# Altium schematic sheet 2_mcu.SchDoc(2_mcu)
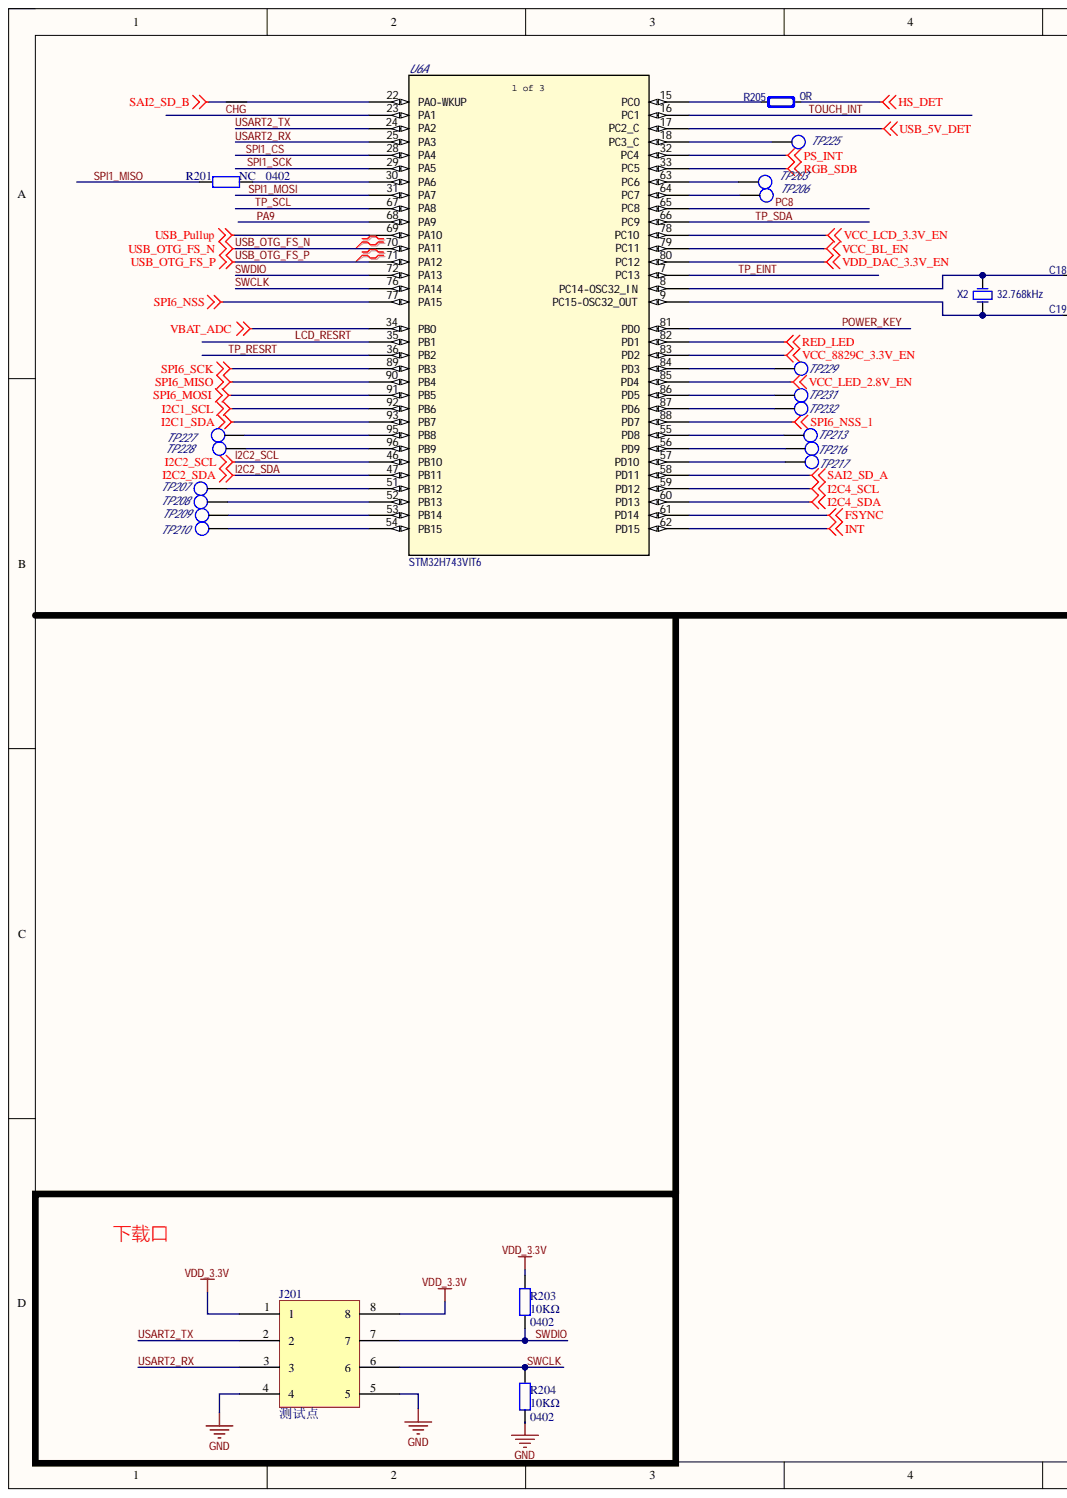
[diagram p0: 2_mcu.SchDoc, left]
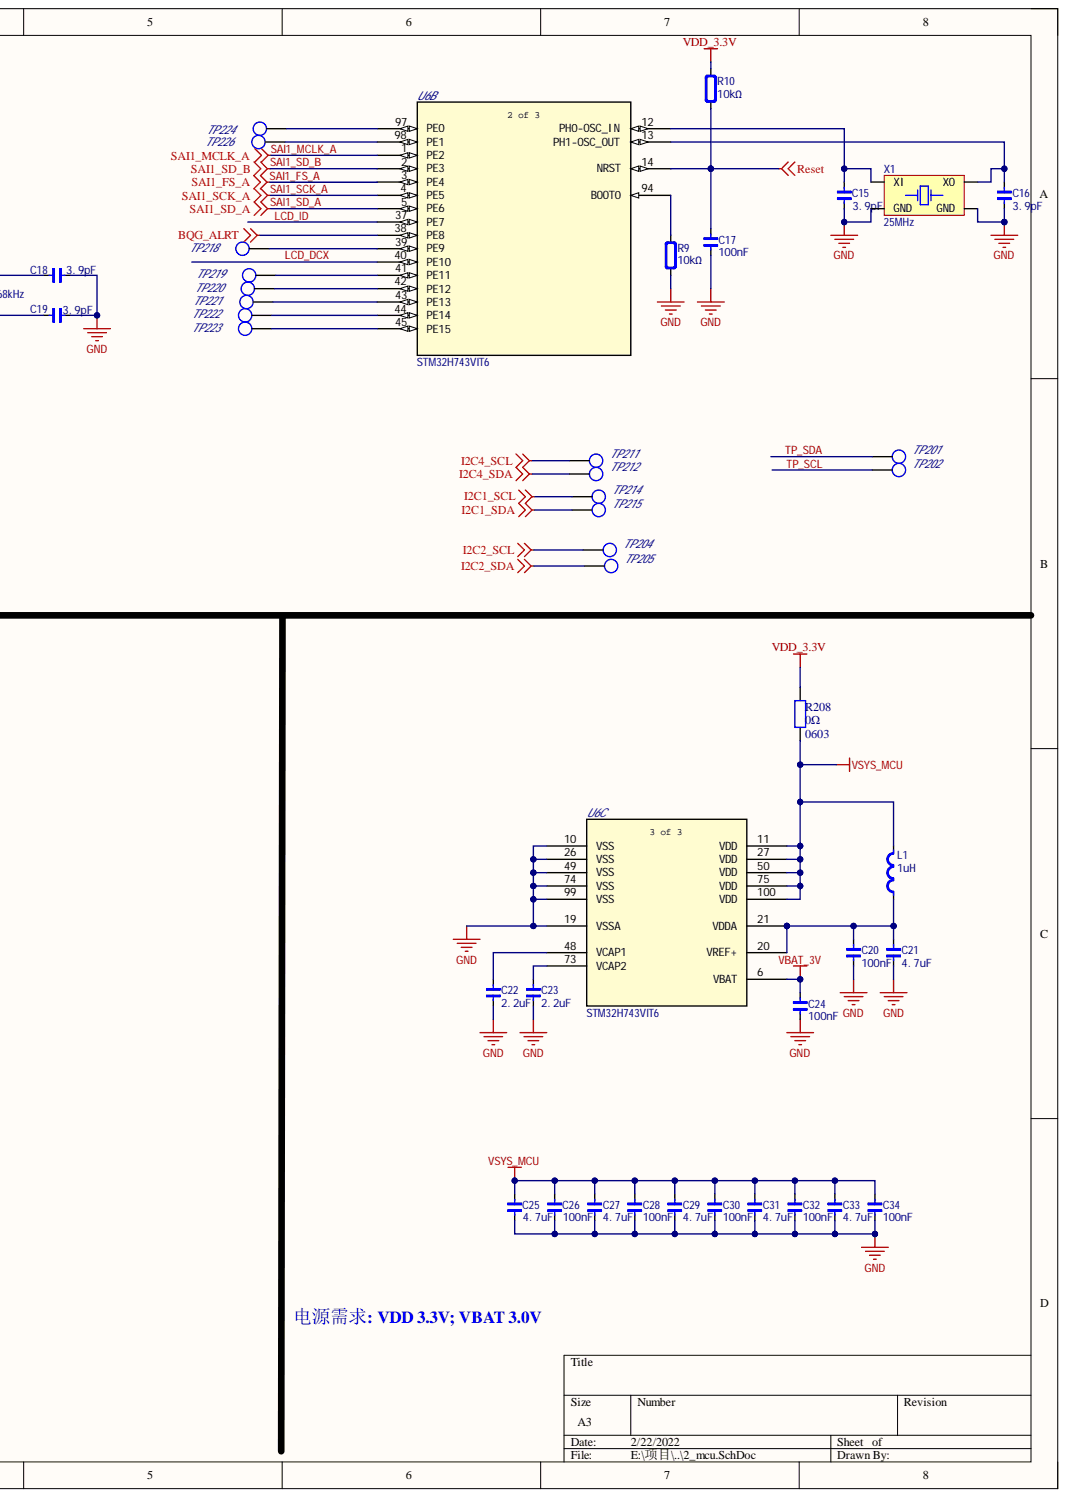
[diagram p1: 2_mcu.SchDoc, right]

## Parts
C15  3.9pF  [0402_C]
C16  3.9pF  [0402_C]
C17  100nF  [0402_C]
C18  3.9pF  [0402_C]
C19  3.9pF  [0402_C]
C20  100nF  [0402_C]
C21  4.7uF  [0402_C]
C22  2.2uF  [0402_C]
C23  2.2uF  [0402_C]
C24  100nF  [0402_C]
C25  4.7uF  [0402_C]
C26  100nF  [0402_C]
C27  4.7uF  [0402_C]
C28  100nF  [0402_C]
C29  4.7uF  [0402_C]
C30  100nF  [0402_C]
C31  4.7uF  [0402_C]
C32  100nF  [0402_C]
C33  4.7uF  [0402_C]
C34  100nF  [0402_C]
J201  测试点  [down]
L1  1uH  [0402_L]
R9  10kΩ  [0402_R]
R10  10kΩ  [0402_R]
R201  NC  Package: 0402  [0402_R]
R203  10KΩ  Package: 0402  [0402_R]
R204  10KΩ  Package: 0402  [0402_R]
R205  0R  [0402_R]
R208  0Ω  Package: 0603  [0603_R]
TP201  (no value)  [0.6mm测试点]
TP202  (no value)  [0.6mm测试点]
TP203  (no value)  [0.6mm测试点]
TP204  (no value)  [0.6mm测试点]
TP205  (no value)  [0.6mm测试点]
TP206  (no value)  [0.6mm测试点]
TP207  (no value)  [0.6mm测试点]
TP208  (no value)  [0.6mm测试点]
TP209  (no value)  [0.6mm测试点]
TP210  (no value)  [0.6mm测试点]
TP211  (no value)  [0.6mm测试点]
TP212  (no value)  [0.6mm测试点]
TP213  (no value)  [0.6mm测试点]
TP214  (no value)  [0.6mm测试点]
TP215  (no value)  [0.6mm测试点]
TP216  (no value)  [0.6mm测试点]
TP217  (no value)  [0.6mm测试点]
TP218  (no value)  [0.6mm测试点]
TP219  (no value)  [0.6mm测试点]
TP220  (no value)  [0.6mm测试点]
TP221  (no value)  [0.6mm测试点]
TP222  (no value)  [0.6mm测试点]
TP223  (no value)  [0.6mm测试点]
TP224  (no value)  [0.6mm测试点]
TP225  (no value)  [0.6mm测试点]
TP226  (no value)  [0.6mm测试点]
TP227  (no value)  [0.6mm测试点]
TP228  (no value)  [0.6mm测试点]
TP229  (no value)  [0.6mm测试点]
TP231  (no value)  [0.6mm测试点]
TP232  (no value)  [0.6mm测试点]
U6  STM32H743VIT6  [LQFP-100_14x14x05P]  (parts A,B,C)
X1  25MHz  [XT_3225_4]
X2  32.768kHz  [XT_3215_2]

## Nets
BQG_ALRT: U6.38(PE8)
FSYNC: U6.61(PD14)
GND: C15.1 C16.1 C17.1 C18.2 C19.2 C20.1 C21.1 C22.1 C23.1 C24.1 C25.1 C26.1 C27.1 C28.1 C29.1 C30.1 C31.1 C32.1 C33.1 C34.1 J201.4 J201.5 R9.1 R204.1 U6.10(VSS) U6.19(VSSA) U6.26(VSS) U6.49(VSS) U6.74(VSS) U6.99(VSS) X1.2(GND) X1.4(GND)
HS_DET: R205.1
I2C1_SCL: TP214.1 U6.92(PB6)
I2C1_SDA: TP215.1 U6.93(PB7)
I2C4_SCL: TP211.1 U6.59(PD12)
I2C4_SDA: TP212.1 U6.60(PD13)
INT: U6.62(PD15)
PS_INT: U6.32(PC4)
RED_LED: U6.82(PD1)
Reset: C17.2 R10.1 U6.14(NRST)
RGB_SDB: U6.33(PC5)
SAI2_SD_A: U6.58(PD11)
SAI2_SD_B: U6.22(PA0-WKUP)
SPI6_MISO: U6.90(PB4)
SPI6_MOSI: U6.91(PB5)
SPI6_NSS: U6.77(PA15)
SPI6_NSS_1: U6.88(PD7)
SPI6_SCK: U6.89(PB3)
USB_5V_DET: U6.17(PC2_C)
USB_Pullup: U6.69(PA10)
VBAT_3V: C24.2 U6.6(VBAT)
VBAT_ADC: U6.34(PB0)
VCC_8829C_3.3V_EN: U6.83(PD2)
VCC_BL_EN: U6.79(PC11)
VCC_LCD_3.3V_EN: U6.78(PC10)
VCC_LED_2.8V_EN: U6.85(PD4)
VDD_3.3V: J201.1 J201.8 R10.2 R203.2 R208.2
VDD_DAC_3.3V_EN: U6.80(PC12)
VSYS_MCU: C25.2 C26.2 C27.2 C28.2 C29.2 C30.2 C31.2 C32.2 C33.2 C34.2 L1.2 R208.1 U6.11(VDD) U6.27(VDD) U6.50(VDD) U6.75(VDD) U6.100(VDD)
~{CHG}: U6.23(PA1)
I2C2_SCL: TP204.1 U6.46(PB10)
I2C2_SDA: TP205.1 U6.47(PB11)
LCD_DCX: U6.40(PE10)
LCD_ID: U6.37(PE7)
LCD_RESRT: U6.35(PB1)
PA9: U6.68(PA9)
PC8: U6.65(PC8)
POWER_KEY: U6.81(PD0)
SAI1_FS_A: U6.3(PE4)
SAI1_MCLK_A: U6.1(PE2)
SAI1_SCK_A: U6.4(PE5)
SAI1_SD_A: U6.5(PE6)
SAI1_SD_B: U6.2(PE3)
SPI1_CS: U6.28(PA4)
SPI1_MISO: R201.1
SPI1_MOSI: U6.31(PA7)
SPI1_SCK: U6.29(PA5)
SWCLK: J201.6 R204.2 U6.76(PA14)
SWDIO: J201.7 R203.1 U6.72(PA13)
TOUCH_INT: U6.16(PC1)
TP_EINT: U6.7(PC13)
TP_RESRT: U6.36(PB2)
TP_SCL: TP202.1 U6.67(PA8)
TP_SDA: TP201.1 U6.66(PC9)
USART2_RX: J201.3 U6.25(PA3)
USART2_TX: J201.2 U6.24(PA2)
USB_OTG_FS_N: U6.70(PA11)
USB_OTG_FS_P: U6.71(PA12)
NetC15_2: C15.2 U6.12(PH0-OSC_IN) X1.1(XI)
NetC16_2: C16.2 U6.13(PH1-OSC_OUT) X1.3(XO)
NetC18_1: C18.1 U6.8(PC14-OSC32_IN) X2.2
NetC19_1: C19.1 U6.9(PC15-OSC32_OUT) X2.1
NetC20_2: C20.2 C21.2 L1.1 U6.20(VREF+) U6.21(VDDA)
NetC22_2: C22.2 U6.48(VCAP1)
NetC23_2: C23.2 U6.73(VCAP2)
NetR9_2: R9.2 U6.94(BOOT0)
NetR201_2: R201.2 U6.30(PA6)
NetR205_2: R205.2 U6.15(PC0)
NetTP203_1: TP203.1 U6.63(PC6)
NetTP206_1: TP206.1 U6.64(PC7)
NetTP207_1: TP207.1 U6.51(PB12)
NetTP208_1: TP208.1 U6.52(PB13)
NetTP209_1: TP209.1 U6.53(PB14)
NetTP210_1: TP210.1 U6.54(PB15)
NetTP213_1: TP213.1 U6.55(PD8)
NetTP216_1: TP216.1 U6.56(PD9)
NetTP217_1: TP217.1 U6.57(PD10)
NetTP218_1: TP218.1 U6.39(PE9)
NetTP219_1: TP219.1 U6.41(PE11)
NetTP220_1: TP220.1 U6.42(PE12)
NetTP221_1: TP221.1 U6.43(PE13)
NetTP222_1: TP222.1 U6.44(PE14)
NetTP223_1: TP223.1 U6.45(PE15)
NetTP224_1: TP224.1 U6.97(PE0)
NetTP225_1: TP225.1 U6.18(PC3_C)
NetTP226_1: TP226.1 U6.98(PE1)
NetTP227_1: TP227.1 U6.95(PB8)
NetTP228_1: TP228.1 U6.96(PB9)
NetTP229_1: TP229.1 U6.84(PD3)
NetTP231_1: TP231.1 U6.86(PD5)
NetTP232_1: TP232.1 U6.87(PD6)

## Library data of the parts
C15-C34 = Cap_0402: Capacitor; Note: ±10%; Specification: X7R, ±10%, 16V; Type: 0402; Component Kind: Standard; Library Name: Integrated_Library.IntLib
J201 = down: 2.54mm的下载测试点，空贴;8个脚；1.2mm的测试点; Component Kind: Standard; Library Name: AD_LIB.IntLib
L1 = Ind_0402: Inductor; Note: ±5%; Specification: High frequency chip inductor ±5%; Type: 0402; Value: ?H; Component Kind: Standard; Library Name: Integrated_Library.IntLib
R9 R10 R205 = Res_0402: Resistor; Note: ±1%; Value: ?K; Component Kind: Standard; Library Name: Integrated_Library.IntLib; Specification: ±1%; Type: 0402
R201 = NC_0402贴片电阻: 贴片电阻; Component Kind: Standard; ComponentLink1Description: 供应商链接; ComponentLink1URL: http://www.szlcsc.com/product/details_26498.html; Library Name: AD_LIB.IntLib
R203 R204 = 0402 10KΩ (1002) 1%: 贴片电阻; Component Kind: Standard; ComponentLink1Description: 供应商链接; ComponentLink1URL: http://www.szlcsc.com/product/details_26487.html; Library Name: Miscellaneous Devices LC.IntLib; Supplier: LC; SuppliersPartNumber: C25744
R208 = 0603 0Ω (0R0) 1%: 贴片电阻; Component Kind: Standard; ComponentLink1Description: 供应商链接; ComponentLink1URL: http://www.szlcsc.com/product/details_21903.html; Library Name: Miscellaneous Devices LC.IntLib; Supplier: LC; SuppliersPartNumber: C21189
TP201-TP229 TP231 TP232 = TestPAD: Component Kind: Standard; Library Name: TW_Lib.SchLib
U6 = STM32H743VIT6: No Description Available; Built_By: EMA_UL_Team; SourceLibrary: Updates_to_database_2020-10-19; Vendor: STMicroelectronics; Component Kind: Standard; Manufacturer_Part_Number: STM32H743VIT6; RefDes: RefDes; Type: DEV; Copyright: Copyright (C) 2018 Accelerated Designs. All rights reserved; Library Name: StdLib_TW.IntLib
X1 = XTAL_3225_4P: Crystal Oscillator; Specification: ±10ppm; Value: ?MHz; Component Kind: Standard; Library Name: Integrated_Library.IntLib; Type: XTAL3225_4P
X2 = XTAL_32.768k_2P: Crystal Oscillator; Specification: ±10ppm; Component Kind: Standard; Library Name: Integrated_Library.IntLib; Type: XTAL2012_2P; Value: 32.768kHz
